# Altium schematic sheet CLOCKANDSWITCH.SchDoc
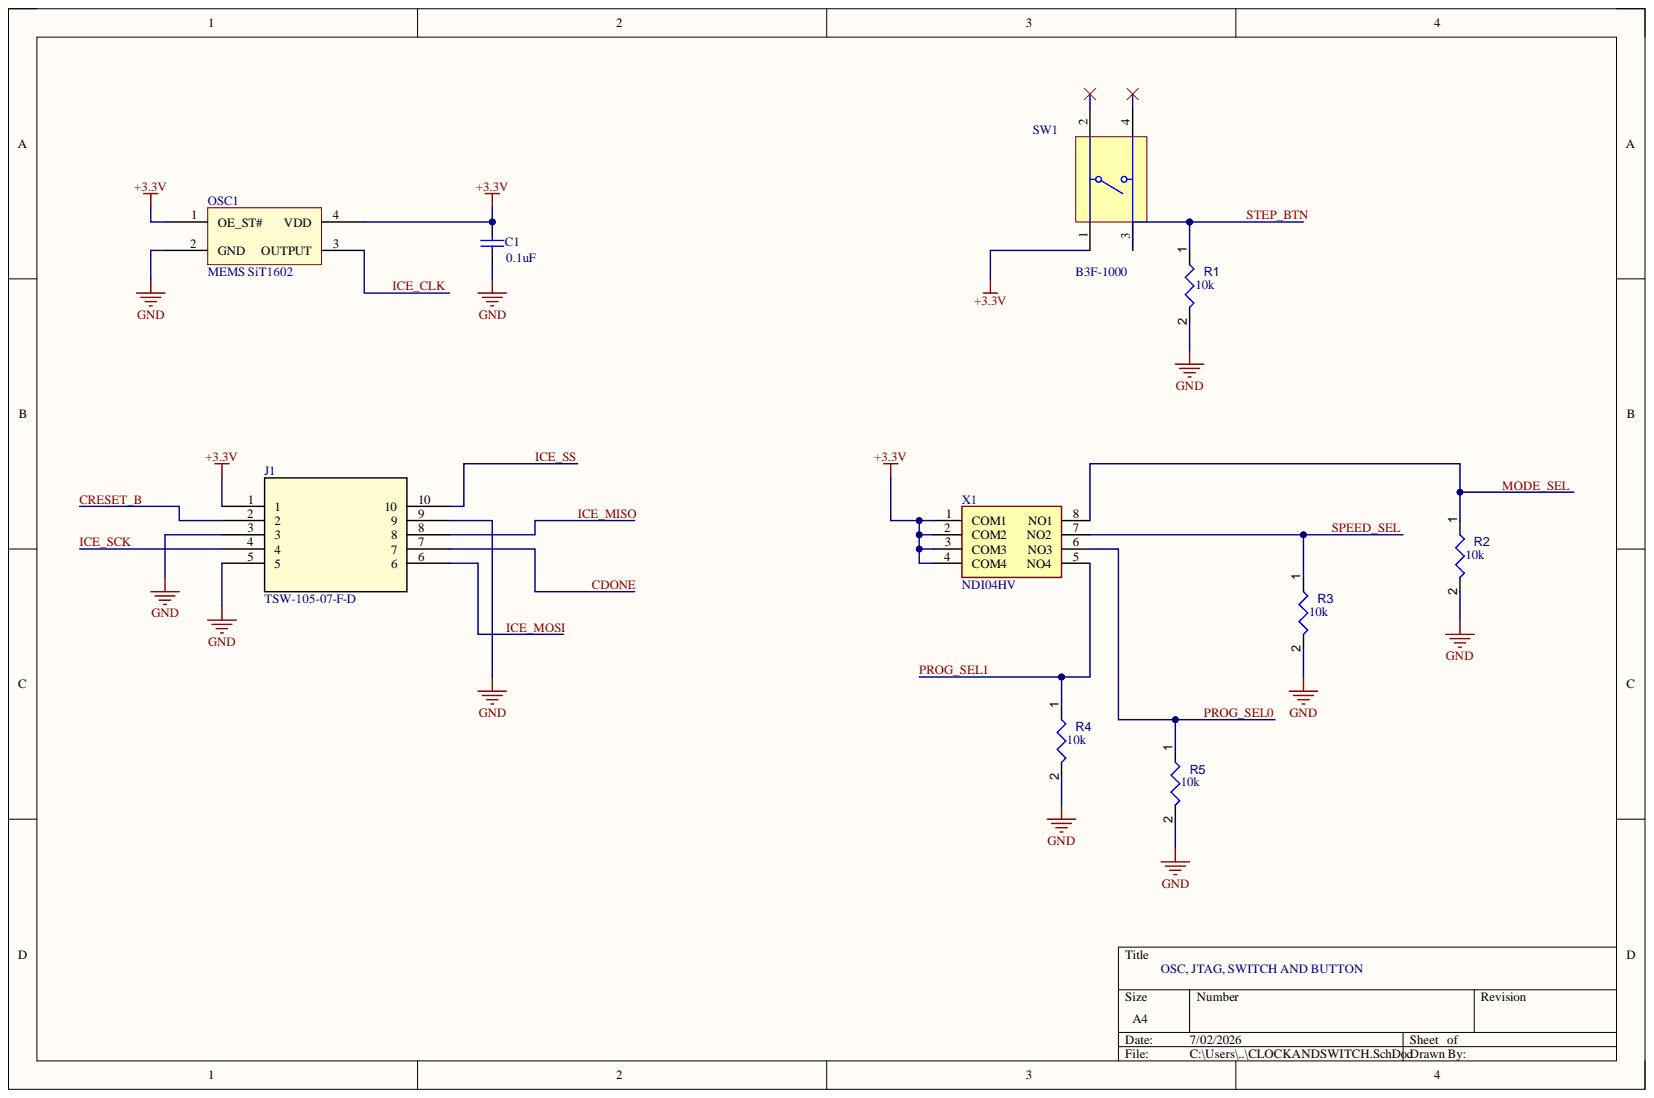
[diagram p0: CLOCKANDSWITCH.SchDoc]

## Parts
C1  value 0.1uF  [C0402]  (hidden comment: Cap Semi)
J1  TSW-105-07-F-D  [TSW-105-07-G-D_SAI]
OSC1  MEMS SiT1602  Base Resonator: MEMS  [oscMEMS1602]
R1  value 10k  [RESC2013X50X35ML15T15]  (hidden comment: Resistor 10k +/-1% 0805 125 mW)
R2  value 10k  [RESC2013X50X35ML15T15]  (hidden comment: Resistor 10k +/-1% 0805 125 mW)
R3  value 10k  [RESC2013X50X35ML15T15]  (hidden comment: Resistor 10k +/-1% 0805 125 mW)
R4  value 10k  [RESC2013X50X35ML15T15]  (hidden comment: Resistor 10k +/-1% 0805 125 mW)
R5  value 10k  [RESC2013X50X35ML15T15]  (hidden comment: Resistor 10k +/-1% 0805 125 mW)
SW1  B3F-1000  [OMRON-B3F-1000-4_V]
X1  NDI04HV  [NDI04HV]

## Nets
+3.3V: C1.2 J1.1 OSC1.1(OE_ST#) OSC1.4(VDD) SW1.1 X1.1(COM1) X1.2(COM2) X1.3(COM3) X1.4(COM4)
GND: C1.1 J1.3 J1.5 J1.9 OSC1.2(GND) R1.2 R2.2 R3.2 R4.2 R5.2
CDONE: J1.7
CRESET_B: J1.2
ICE_CLK: OSC1.3(OUTPUT)
ICE_MISO: J1.8
ICE_MOSI: J1.6
ICE_SCK: J1.4
ICE_SS: J1.10
MODE_SEL: R2.1 X1.8(NO1)
PROG_SEL0: R5.1 X1.6(NO3)
PROG_SEL1: R4.1 X1.5(NO4)
SPEED_SEL: R3.1 X1.7(NO2)
STEP_BTN: R1.1 SW1.3

Not connected: SW1 2 4

## Library data of the parts
C1 = Cap Semi: Capacitor (Semiconductor SIM Model); Manufacturer: Samsung Electro-Mechanics; Manufacturer Part Number: CL05B104KA5NNNC; Notification: Current market demand for these product types have resulted in fluctuating and extending lead times. Lead times may differ.; Temperature Coefficient: X7R; Tolerance: ±10%; Voltage - Rated: 25V; Applications: General Purpose; Category: Capacitors; Component Kind: Standard; ComponentLink1Description: http://www.samsungsem.com/kr/support/product-search/mlcc/CL05B104KA5NNNC.jsp; ComponentLink1URL: http://www.samsungsem.com/kr/support/product-search/mlcc/CL05B104KA5NNNC.jsp; Features: -; Height - Seated (Max): -; LatestRevisionDate: 29-May-2009; LatestRevisionNote: IPC-7351 Footprint Added.; Lead Spacing: -; Lead Style: -; Library Name: Miscellaneous Devices.IntLib; Mounting Type: Surface Mount, MLCC; Operating Temperature: -55°C ~ 125°C; Package / Case: 0402 (1005 Metric); PackageDescription: Chip Capacitor; PackageReference: C1206; Packaging: Cut Tape (CT); Part Status: Active; Pricing 1: 1=0.1, 10=0.071, 100=0.0317, 500=0.02262, 1000=0.01777, 2500=0.01616, 5000=0.01486 (USD); Published: 8-Jun-2000; Ratings: -; RoHS: RoHS Compliant; Signal Integrity: Cap Semi; Simulation: CAP; Size / Dimension: 0.039" L x 0.020" W (1.00mm x 0.50mm); Stock 1: 0; Supplier 1: Digi-Key; Supplier Part Number 1: 1276-6720-1-ND; Thickness (Max): 0.022" (0.55mm); Publisher: Altium Limited
J1 = CMP-007-000000-1: Description; Manufacturer_Name: Samtec Inc; Component Kind: Standard; Design Item ID: TSW-105-07-F-D; Manufacturer_Part_Number: TSW-105-07-F-D; RefDes: RefDes; Source: TSW-105-07-F-D.SchLib; Type: Type; Copyright: Copyright (C) 2025 Ultra Librarian. All rights reserved.; Library Name: Talha Khan
OSC1 = SIT1602AC-13-33E-12.000000G: MEMS OSC XO 12.0000MHZ LVCMOS; Manufacturer: SiTIME; Current - Supply (Disable) (Max): 4mA; Current - Supply (Max): 4.5mA; Frequency Stability: ±50ppm; Function: Enable/Disable; Voltage - Supply: 3.3V; Category: Crystals, Oscillators, Resonators; Component Kind: Standard; ComponentLink1Description: https://media.digikey.com/pdf/Data%20Sheets/SiTime%20PDFs/SIT1602A.pdf; ComponentLink1URL: https://media.digikey.com/pdf/Data%20Sheets/SiTime%20PDFs/SIT1602A.pdf; Height - Seated (Max): 0.032" (0.80mm); Manufacturer Part Number: SIT1602AC-13-33E-12.000000G; Mounting Type: Surface Mount; Operating Temperature: -20°C ~ 70°C; Output: LVCMOS; Package / Case: 4-SMD, No Lead; Packaging: Cut Tape (CT); Part Status: Not For New Designs; Pricing 1: 1=1.26, 10=1.118, 50=1.045, 100=0.9236 (USD); Ratings: -; RoHS: RoHS Compliant; Size / Dimension: 0.098" L x 0.079" W (2.50mm x 2.00mm); Supplier 1: Digi-Key; Supplier Part Number 1: 1473-1051-1-ND; Type: XO (Standard); Library Name: FPGAlib.SchLib
R1-R5 = CMP-009-00018-5: Chip Resistor, 10 KOhm, +/- 1%, 125 mW, -55 to 155 degC, 0805 (2012 Metric); Power: 125mW; Voltage Rating: 150V; Case/Package: 0805; Component Kind: Standard; Max Operating Temperature: 155°C; Min Operating Temperature: -55°C; Mounting Technology: SM; Pins: 2; RoHS Compliant: True; Tolerance: 1%; Library Name: Talha Khan
SW1 = CMP-011-00004-2: Tactile Switch, SPST-NO, 0.05 A, 24 V, -25 to 70 degC, 4-Pin THD, RoHS, Bulk; Manufacturer: Omron Electronic Components; Contact Current Rating: 50mA; Max Contact Resistance: 100mohm; Max Voltage Rating (DC): 24V; Operating Force: 100gf; Resistance: 0.1Ohm; Throw Configuration: SPST; Case/Package: B3F-1000; Component Kind: Standard; Contact Material: Gold; Contact Plating: Gold; Current Rating: 50mA; Datasheet URL: http://www.omron.com/ecb/products/pdf/en-b3f.pdf; Datasheet Version: Jan-15; Manufacturer URL: http://www.omron.com/; Max Current Rating: 50mA; Max Operating Temperature: 70°C; Min Operating Temperature: -25°C; Mounting Technology: TH; Package Description: 4-Pin Through Hole Device, Body 6 x 6 mm; Package Quantity: 1500; Package Reference: B3F-1000; Packaging: Bulk; Pins: 4; RoHS Compliant: True; Voltage Rating: 24V; Voltage Rating (DC): 24V; Library Name: Talha Khan
X1 = CMP-014-000000-1: Dip Switch SPST 4 Position Through Hole Slide (Standard) Actuator 25mA 24VDC; Manufacturer_Name: Apem; Geometry.Height: 5mm; Component Kind: Standard; Datasheet Link: https://www.mouser.ca/datasheet/2/26/NDI_DM_DIPS-276092.pdf; Design Item ID: NDI04HV; Manufacturer_Part_Number: NDI04HV; Mouser Part Number: 642-NDI04HV; Mouser Price/Stock: https://www.mouser.co.uk/ProductDetail/Apem/NDI04HV/?qs=4pzlT8jjbheYf%2FxKjHex%252BQ%3D%3D; Source: NDI04HV.SchLib; Library Name: Talha Khan
